# Altium schematic sheet connection2.SchDoc
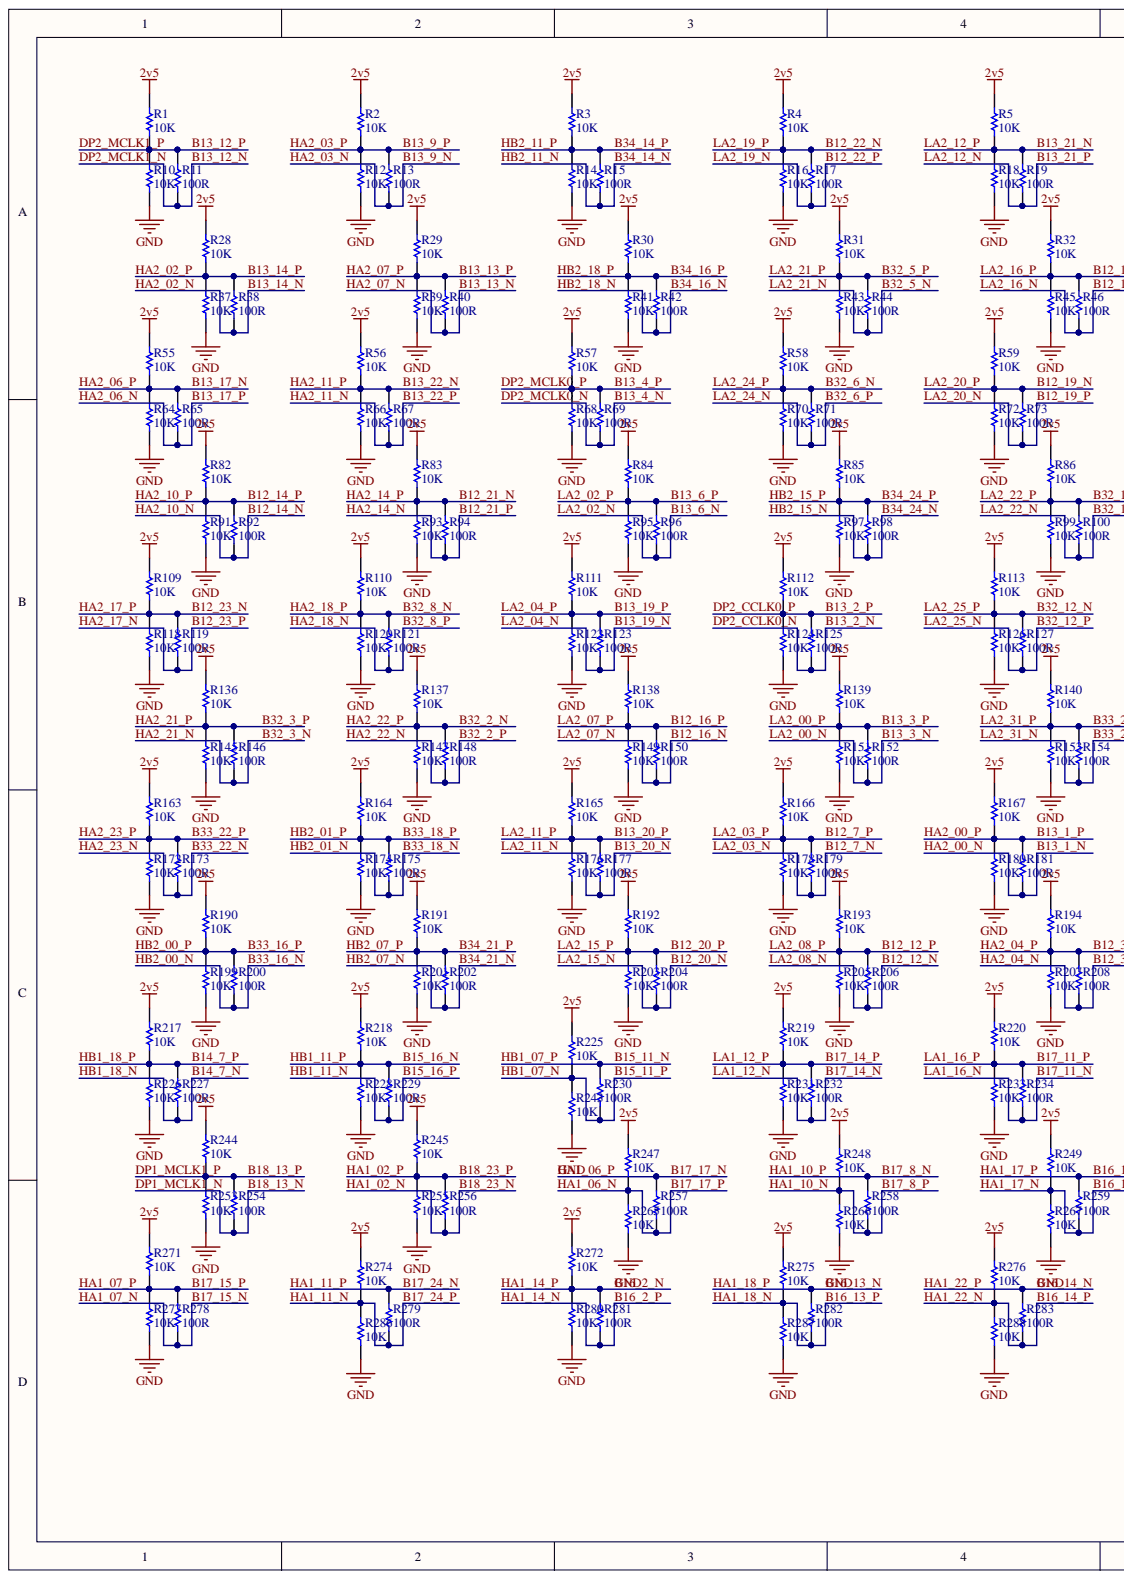
[diagram p0: connection2.SchDoc, left]
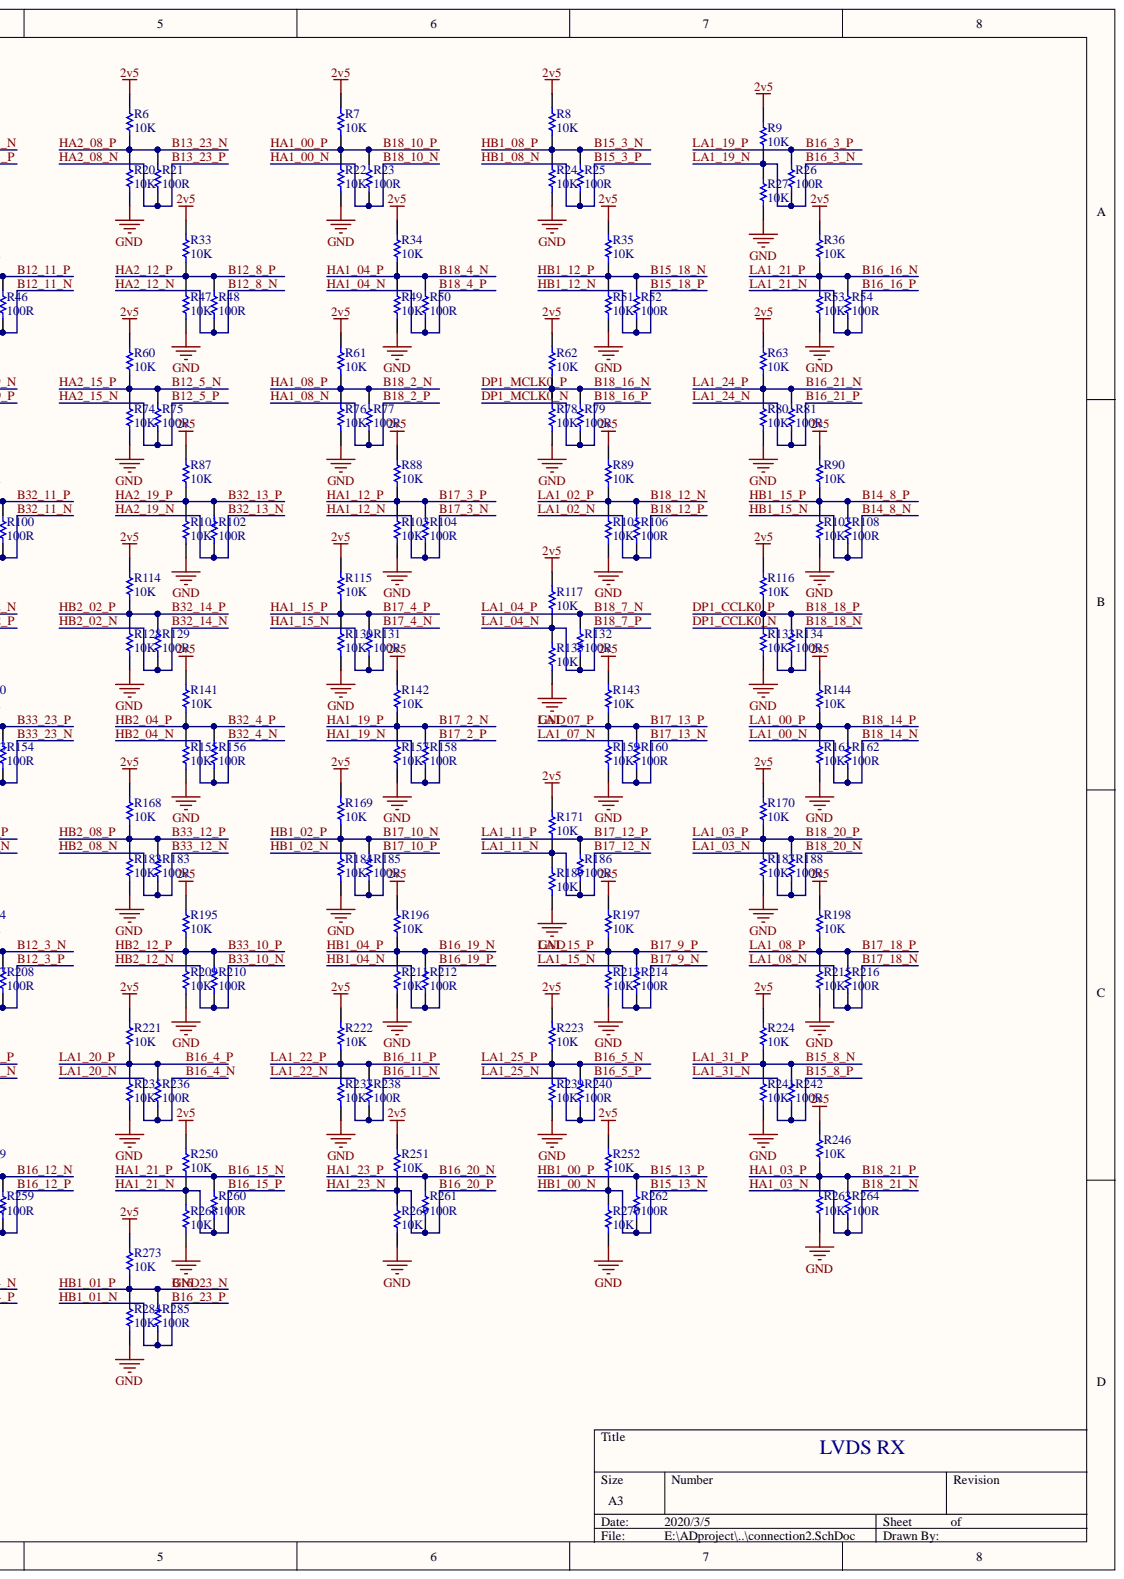
[diagram p1: connection2.SchDoc, right]

## Parts
R1  value 10K  [0402]
R2  value 10K  [0402]
R3  value 10K  [0402]
R4  value 10K  [0402]
R5  value 10K  [0402]
R6  value 10K  [0402]
R7  value 10K  [0402]
R8  value 10K  [0402]
R9  value 10K  [0402]
R10  value 10K  [0402]
R11  value 100R  [0402]
R12  value 10K  [0402]
R13  value 100R  [0402]
R14  value 10K  [0402]
R15  value 100R  [0402]
R16  value 10K  [0402]
R17  value 100R  [0402]
R18  value 10K  [0402]
R19  value 100R  [0402]
R20  value 10K  [0402]
R21  value 100R  [0402]
R22  value 10K  [0402]
R23  value 100R  [0402]
R24  value 10K  [0402]
R25  value 100R  [0402]
R26  value 100R  [0402]
R27  value 10K  [0402]
R28  value 10K  [0402]
R29  value 10K  [0402]
R30  value 10K  [0402]
R31  value 10K  [0402]
R32  value 10K  [0402]
R33  value 10K  [0402]
R34  value 10K  [0402]
R35  value 10K  [0402]
R36  value 10K  [0402]
R37  value 10K  [0402]
R38  value 100R  [0402]
R39  value 10K  [0402]
R40  value 100R  [0402]
R41  value 10K  [0402]
R42  value 100R  [0402]
R43  value 10K  [0402]
R44  value 100R  [0402]
R45  value 10K  [0402]
R46  value 100R  [0402]
R47  value 10K  [0402]
R48  value 100R  [0402]
R49  value 10K  [0402]
R50  value 100R  [0402]
R51  value 10K  [0402]
R52  value 100R  [0402]
R53  value 10K  [0402]
R54  value 100R  [0402]
R55  value 10K  [0402]
R56  value 10K  [0402]
R57  value 10K  [0402]
R58  value 10K  [0402]
R59  value 10K  [0402]
R60  value 10K  [0402]
R61  value 10K  [0402]
R62  value 10K  [0402]
R63  value 10K  [0402]
R64  value 10K  [0402]
R65  value 100R  [0402]
R66  value 10K  [0402]
R67  value 100R  [0402]
R68  value 10K  [0402]
R69  value 100R  [0402]
R70  value 10K  [0402]
R71  value 100R  [0402]
R72  value 10K  [0402]
R73  value 100R  [0402]
R74  value 10K  [0402]
R75  value 100R  [0402]
R76  value 10K  [0402]
R77  value 100R  [0402]
R78  value 10K  [0402]
R79  value 100R  [0402]
R80  value 10K  [0402]
R81  value 100R  [0402]
R82  value 10K  [0402]
R83  value 10K  [0402]
R84  value 10K  [0402]
R85  value 10K  [0402]
R86  value 10K  [0402]
R87  value 10K  [0402]
R88  value 10K  [0402]
R89  value 10K  [0402]
R90  value 10K  [0402]
R91  value 10K  [0402]
R92  value 100R  [0402]
R93  value 10K  [0402]
R94  value 100R  [0402]
R95  value 10K  [0402]
R96  value 100R  [0402]
R97  value 10K  [0402]
R98  value 100R  [0402]
R99  value 10K  [0402]
R100  value 100R  [0402]
R101  value 10K  [0402]
R102  value 100R  [0402]
R103  value 10K  [0402]
R104  value 100R  [0402]
R105  value 10K  [0402]
R106  value 100R  [0402]
R107  value 10K  [0402]
R108  value 100R  [0402]
R109  value 10K  [0402]
R110  value 10K  [0402]
R111  value 10K  [0402]
R112  value 10K  [0402]
R113  value 10K  [0402]
R114  value 10K  [0402]
R115  value 10K  [0402]
R116  value 10K  [0402]
R117  value 10K  [0402]
R118  value 10K  [0402]
R119  value 100R  [0402]
R120  value 10K  [0402]
R121  value 100R  [0402]
R122  value 10K  [0402]
R123  value 100R  [0402]
R124  value 10K  [0402]
R125  value 100R  [0402]
R126  value 10K  [0402]
R127  value 100R  [0402]
R128  value 10K  [0402]
R129  value 100R  [0402]
R130  value 10K  [0402]
R131  value 100R  [0402]
R132  value 100R  [0402]
R133  value 10K  [0402]
R134  value 100R  [0402]
R135  value 10K  [0402]
R136  value 10K  [0402]
R137  value 10K  [0402]
R138  value 10K  [0402]
R139  value 10K  [0402]
R140  value 10K  [0402]
R141  value 10K  [0402]
R142  value 10K  [0402]
R143  value 10K  [0402]
R144  value 10K  [0402]
R145  value 10K  [0402]
R146  value 100R  [0402]
R147  value 10K  [0402]
R148  value 100R  [0402]
R149  value 10K  [0402]
R150  value 100R  [0402]
R151  value 10K  [0402]
R152  value 100R  [0402]
R153  value 10K  [0402]
R154  value 100R  [0402]
R155  value 10K  [0402]
R156  value 100R  [0402]
R157  value 10K  [0402]
R158  value 100R  [0402]
R159  value 10K  [0402]
R160  value 100R  [0402]
R161  value 10K  [0402]
R162  value 100R  [0402]
R163  value 10K  [0402]
R164  value 10K  [0402]
R165  value 10K  [0402]
R166  value 10K  [0402]
R167  value 10K  [0402]
R168  value 10K  [0402]
R169  value 10K  [0402]
R170  value 10K  [0402]
R171  value 10K  [0402]
R172  value 10K  [0402]
R173  value 100R  [0402]
R174  value 10K  [0402]
R175  value 100R  [0402]
R176  value 10K  [0402]
R177  value 100R  [0402]
R178  value 10K  [0402]
R179  value 100R  [0402]
R180  value 10K  [0402]
R181  value 100R  [0402]
R182  value 10K  [0402]
R183  value 100R  [0402]
R184  value 10K  [0402]
R185  value 100R  [0402]
R186  value 100R  [0402]
R187  value 10K  [0402]
R188  value 100R  [0402]
R189  value 10K  [0402]
R190  value 10K  [0402]
R191  value 10K  [0402]
R192  value 10K  [0402]
R193  value 10K  [0402]
R194  value 10K  [0402]
R195  value 10K  [0402]
R196  value 10K  [0402]
R197  value 10K  [0402]
R198  value 10K  [0402]
R199  value 10K  [0402]
R200  value 100R  [0402]
R201  value 10K  [0402]
R202  value 100R  [0402]
R203  value 10K  [0402]
R204  value 100R  [0402]
R205  value 10K  [0402]
R206  value 100R  [0402]
R207  value 10K  [0402]
R208  value 100R  [0402]
R209  value 10K  [0402]
R210  value 100R  [0402]
R211  value 10K  [0402]
R212  value 100R  [0402]
R213  value 10K  [0402]
R214  value 100R  [0402]
R215  value 10K  [0402]
R216  value 100R  [0402]
R217  value 10K  [0402]
R218  value 10K  [0402]
R219  value 10K  [0402]
R220  value 10K  [0402]
R221  value 10K  [0402]
R222  value 10K  [0402]
R223  value 10K  [0402]
R224  value 10K  [0402]
R225  value 10K  [0402]
R226  value 10K  [0402]
R227  value 100R  [0402]
R228  value 10K  [0402]
R229  value 100R  [0402]
R230  value 100R  [0402]
R231  value 10K  [0402]
R232  value 100R  [0402]
R233  value 10K  [0402]
R234  value 100R  [0402]
R235  value 10K  [0402]
R236  value 100R  [0402]
R237  value 10K  [0402]
R238  value 100R  [0402]
R239  value 10K  [0402]
R240  value 100R  [0402]
R241  value 10K  [0402]
R242  value 100R  [0402]
R243  value 10K  [0402]
R244  value 10K  [0402]
R245  value 10K  [0402]
R246  value 10K  [0402]
R247  value 10K  [0402]
R248  value 10K  [0402]
R249  value 10K  [0402]
R250  value 10K  [0402]
R251  value 10K  [0402]
R252  value 10K  [0402]
R253  value 10K  [0402]
R254  value 100R  [0402]
R255  value 10K  [0402]
R256  value 100R  [0402]
R257  value 100R  [0402]
R258  value 100R  [0402]
R259  value 100R  [0402]
R260  value 100R  [0402]
R261  value 100R  [0402]
R262  value 100R  [0402]
R263  value 10K  [0402]
R264  value 100R  [0402]
R265  value 10K  [0402]
R266  value 10K  [0402]
R267  value 10K  [0402]
R268  value 10K  [0402]
R269  value 10K  [0402]
R270  value 10K  [0402]
R271  value 10K  [0402]
R272  value 10K  [0402]
R273  value 10K  [0402]
R274  value 10K  [0402]
R275  value 10K  [0402]
R276  value 10K  [0402]
R277  value 10K  [0402]
R278  value 100R  [0402]
R279  value 100R  [0402]
R280  value 10K  [0402]
R281  value 100R  [0402]
R282  value 100R  [0402]
R283  value 100R  [0402]
R284  value 10K  [0402]
R285  value 100R  [0402]
R286  value 10K  [0402]
R287  value 10K  [0402]
R288  value 10K  [0402]

## Nets
2v5: R1.1 R2.1 R3.1 R4.1 R5.1 R6.1 R7.1 R8.1 R9.1 R28.1 R29.1 R30.1 R31.1 R32.1 R33.1 R34.1 R35.1 R36.1 R55.1 R56.1 R57.1 R58.1 R59.1 R60.1 R61.1 R62.1 R63.1 R82.1 R83.1 R84.1 R85.1 R86.1 R87.1 R88.1 R89.1 R90.1 R109.1 R110.1 R111.1 R112.1 R113.1 R114.1 R115.1 R116.1 R117.1 R136.1 R137.1 R138.1 R139.1 R140.1 R141.1 R142.1 R143.1 R144.1 R163.1 R164.1 R165.1 R166.1 R167.1 R168.1 R169.1 R170.1 R171.1 R190.1 R191.1 R192.1 R193.1 R194.1 R195.1 R196.1 R197.1 R198.1 R217.1 R218.1 R219.1 R220.1 R221.1 R222.1 R223.1 R224.1 R225.1 R244.1 R245.1 R246.1 R247.1 R248.1 R249.1 R250.1 R251.1 R252.1 R271.1 R272.1 R273.1 R274.1 R275.1 R276.1
GND: R10.2 R12.2 R14.2 R16.2 R18.2 R20.2 R22.2 R24.2 R27.2 R37.2 R39.2 R41.2 R43.2 R45.2 R47.2 R49.2 R51.2 R53.2 R64.2 R66.2 R68.2 R70.2 R72.2 R74.2 R76.2 R78.2 R80.2 R91.2 R93.2 R95.2 R97.2 R99.2 R101.2 R103.2 R105.2 R107.2 R118.2 R120.2 R122.2 R124.2 R126.2 R128.2 R130.2 R133.2 R135.2 R145.2 R147.2 R149.2 R151.2 R153.2 R155.2 R157.2 R159.2 R161.2 R172.2 R174.2 R176.2 R178.2 R180.2 R182.2 R184.2 R187.2 R189.2 R199.2 R201.2 R203.2 R205.2 R207.2 R209.2 R211.2 R213.2 R215.2 R226.2 R228.2 R231.2 R233.2 R235.2 R237.2 R239.2 R241.2 R243.2 R253.2 R255.2 R263.2 R265.2 R266.2 R267.2 R268.2 R269.2 R270.2 R277.2 R280.2 R284.2 R286.2 R287.2 R288.2
B12_3_N: R194.2 R207.1 R208.2
B12_3_P: R208.1
B12_5_N: R60.2 R74.1 R75.2
B12_5_P: R75.1
B12_7_N: R179.1
B12_7_P: R166.2 R178.1 R179.2
B12_8_N: R48.1
B12_8_P: R33.2 R47.1 R48.2
B12_11_N: R46.1
B12_11_P: R32.2 R45.1 R46.2
B12_12_N: R206.1
B12_12_P: R193.2 R205.1 R206.2
B12_14_N: R92.1
B12_14_P: R82.2 R91.1 R92.2
B12_16_N: R150.1
B12_16_P: R138.2 R149.1 R150.2
B12_19_N: R59.2 R72.1 R73.2
B12_19_P: R73.1
B12_20_N: R204.1
B12_20_P: R192.2 R203.1 R204.2
B12_21_N: R83.2 R93.1 R94.2
B12_21_P: R94.1
B12_22_N: R4.2 R16.1 R17.2
B12_22_P: R17.1
B12_23_N: R109.2 R118.1 R119.2
B12_23_P: R119.1
B13_1_N: R181.1
B13_1_P: R167.2 R180.1 R181.2
B13_2_N: R125.1
B13_2_P: R112.2 R124.1 R125.2
B13_3_N: R152.1
B13_3_P: R139.2 R151.1 R152.2
B13_4_N: R69.1
B13_4_P: R57.2 R68.1 R69.2
B13_6_N: R96.1
B13_6_P: R84.2 R95.1 R96.2
B13_9_N: R13.1
B13_9_P: R2.2 R12.1 R13.2
B13_12_N: R11.1
B13_12_P: R1.2 R10.1 R11.2
B13_13_N: R40.1
B13_13_P: R29.2 R39.1 R40.2
B13_14_N: R38.1
B13_14_P: R28.2 R37.1 R38.2
B13_17_N: R55.2 R64.1 R65.2
B13_17_P: R65.1
B13_19_N: R123.1
B13_19_P: R111.2 R122.1 R123.2
B13_20_N: R177.1
B13_20_P: R165.2 R176.1 R177.2
B13_21_N: R5.2 R18.1 R19.2
B13_21_P: R19.1
B13_22_N: R56.2 R66.1 R67.2
B13_22_P: R67.1
B13_23_N: R6.2 R20.1 R21.2
B13_23_P: R21.1
B14_7_N: R227.1
B14_7_P: R217.2 R226.1 R227.2
B14_8_N: R108.1
B14_8_P: R90.2 R107.1 R108.2
B15_3_N: R8.2 R24.1 R25.2
B15_3_P: R25.1
B15_8_N: R224.2 R241.1 R242.2
B15_8_P: R242.1
B15_11_N: R230.2
B15_11_P: R225.2 R230.1 R243.1
B15_13_N: R252.2 R262.1 R270.1
B15_13_P: R262.2
B15_16_N: R218.2 R228.1 R229.2
B15_16_P: R229.1
B15_18_N: R35.2 R51.1 R52.2
B15_18_P: R52.1
B16_2_N: R272.2 R280.1 R281.2
B16_2_P: R281.1
B16_3_N: R9.2 R26.1 R27.1
B16_3_P: R26.2
B16_4_N: R236.1
B16_4_P: R221.2 R235.1 R236.2
B16_5_N: R223.2 R239.1 R240.2
B16_5_P: R240.1
B16_11_N: R238.1
B16_11_P: R222.2 R237.1 R238.2
B16_12_N: R259.2
B16_12_P: R249.2 R259.1 R267.1
B16_13_N: R282.2
B16_13_P: R275.2 R282.1 R287.1
B16_14_N: R283.2
B16_14_P: R276.2 R283.1 R288.1
B16_15_N: R260.2
B16_15_P: R250.2 R260.1 R268.1
B16_16_N: R36.2 R53.1 R54.2
B16_16_P: R54.1
B16_19_N: R196.2 R211.1 R212.2
B16_19_P: R212.1
B16_20_N: R261.2
B16_20_P: R251.2 R261.1 R269.1
B16_21_N: R63.2 R80.1 R81.2
B16_21_P: R81.1
B16_23_N: R273.2 R284.1 R285.2
B16_23_P: R285.1
B17_2_N: R142.2 R157.1 R158.2
B17_2_P: R158.1
B17_3_N: R104.1
B17_3_P: R88.2 R103.1 R104.2
B17_4_N: R131.1
B17_4_P: R115.2 R130.1 R131.2
B17_8_N: R258.2
B17_8_P: R248.2 R258.1 R266.1
B17_9_N: R214.1
B17_9_P: R197.2 R213.1 R214.2
B17_10_N: R169.2 R184.1 R185.2
B17_10_P: R185.1
B17_11_N: R234.1
B17_11_P: R220.2 R233.1 R234.2
B17_12_N: R171.2 R186.1 R189.1
B17_12_P: R186.2
B17_13_N: R160.1
B17_13_P: R143.2 R159.1 R160.2
B17_14_N: R232.1
B17_14_P: R219.2 R231.1 R232.2
B17_15_N: R278.1
B17_15_P: R271.2 R277.1 R278.2
B17_17_N: R257.2
B17_17_P: R247.2 R257.1 R265.1
B17_18_N: R216.1
B17_18_P: R198.2 R215.1 R216.2
B17_24_N: R279.2
B17_24_P: R274.2 R279.1 R286.1
B18_2_N: R61.2 R76.1 R77.2
B18_2_P: R77.1
B18_4_N: R34.2 R49.1 R50.2
B18_4_P: R50.1
B18_7_N: R132.2
B18_7_P: R117.2 R132.1 R135.1
B18_10_N: R23.1
B18_10_P: R7.2 R22.1 R23.2
B18_12_N: R89.2 R105.1 R106.2
B18_12_P: R106.1
B18_13_N: R254.1
B18_13_P: R244.2 R253.1 R254.2
B18_14_N: R162.1
B18_14_P: R144.2 R161.1 R162.2
B18_16_N: R62.2 R78.1 R79.2
B18_16_P: R79.1
B18_18_N: R134.1
B18_18_P: R116.2 R133.1 R134.2
B18_20_N: R188.1
B18_20_P: R170.2 R187.1 R188.2
B18_21_N: R264.1
B18_21_P: R246.2 R263.1 R264.2
B18_23_N: R256.1
B18_23_P: R245.2 R255.1 R256.2
B32_2_N: R137.2 R147.1 R148.2
B32_2_P: R148.1
B32_3_N: R146.1
B32_3_P: R136.2 R145.1 R146.2
B32_4_N: R156.1
B32_4_P: R141.2 R155.1 R156.2
B32_5_N: R44.1
B32_5_P: R31.2 R43.1 R44.2
B32_6_N: R58.2 R70.1 R71.2
B32_6_P: R71.1
B32_8_N: R110.2 R120.1 R121.2
B32_8_P: R121.1
B32_11_N: R100.1
B32_11_P: R86.2 R99.1 R100.2
B32_12_N: R113.2 R126.1 R127.2
B32_12_P: R127.1
B32_13_N: R102.1
B32_13_P: R87.2 R101.1 R102.2
B32_14_N: R129.1
B32_14_P: R114.2 R128.1 R129.2
B33_10_N: R210.1
B33_10_P: R195.2 R209.1 R210.2
B33_12_N: R183.1
B33_12_P: R168.2 R182.1 R183.2
B33_16_N: R200.1
B33_16_P: R190.2 R199.1 R200.2
B33_18_N: R175.1
B33_18_P: R164.2 R174.1 R175.2
B33_22_N: R173.1
B33_22_P: R163.2 R172.1 R173.2
B33_23_N: R154.1
B33_23_P: R140.2 R153.1 R154.2
B34_14_N: R15.1
B34_14_P: R3.2 R14.1 R15.2
B34_16_N: R42.1
B34_16_P: R30.2 R41.1 R42.2
B34_21_N: R202.1
B34_21_P: R191.2 R201.1 R202.2
B34_24_N: R98.1
B34_24_P: R85.2 R97.1 R98.2

## Library data of the parts
R1-R288 = CMP-1012-00213-1: Case-EIA: 0603; Case-Metric: 1608; Power: 0.1 W; Tolerance: 1 %; Component Kind: Standard; Library Name: Altium Content Vault; Technology: SMT
